# Altium schematic sheet Main.SchDoc(Main)
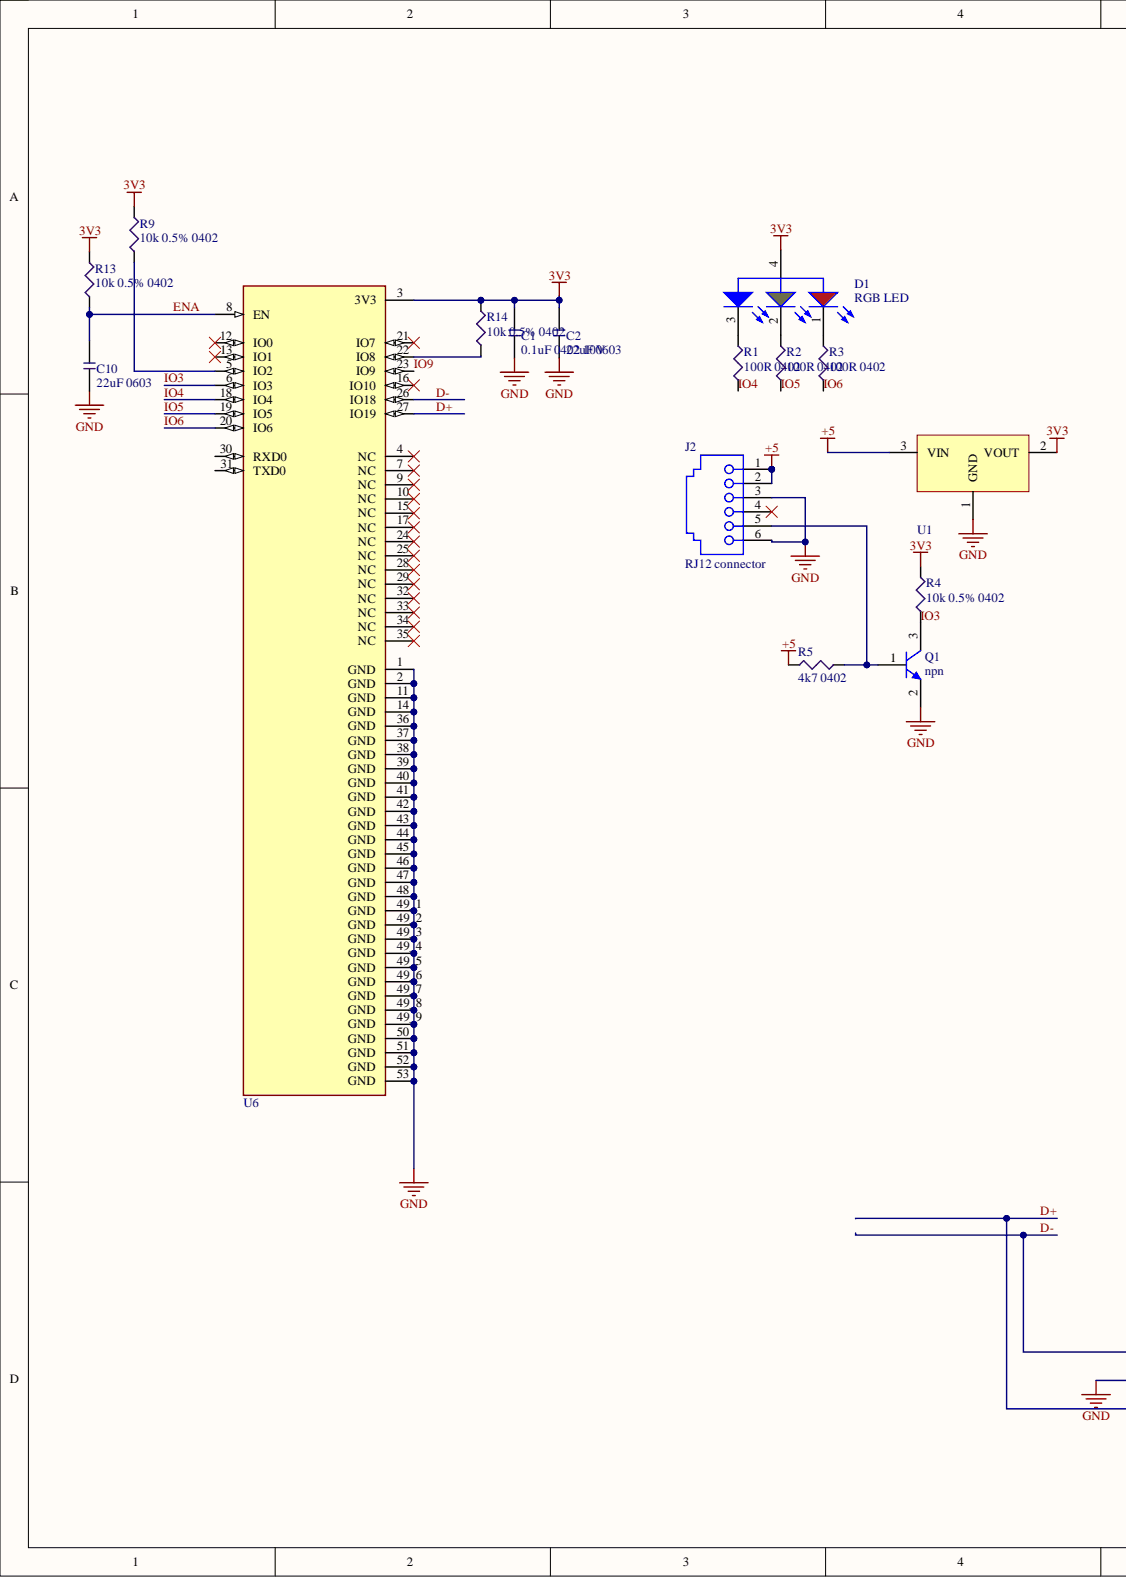
[diagram p0: Main.SchDoc, left]
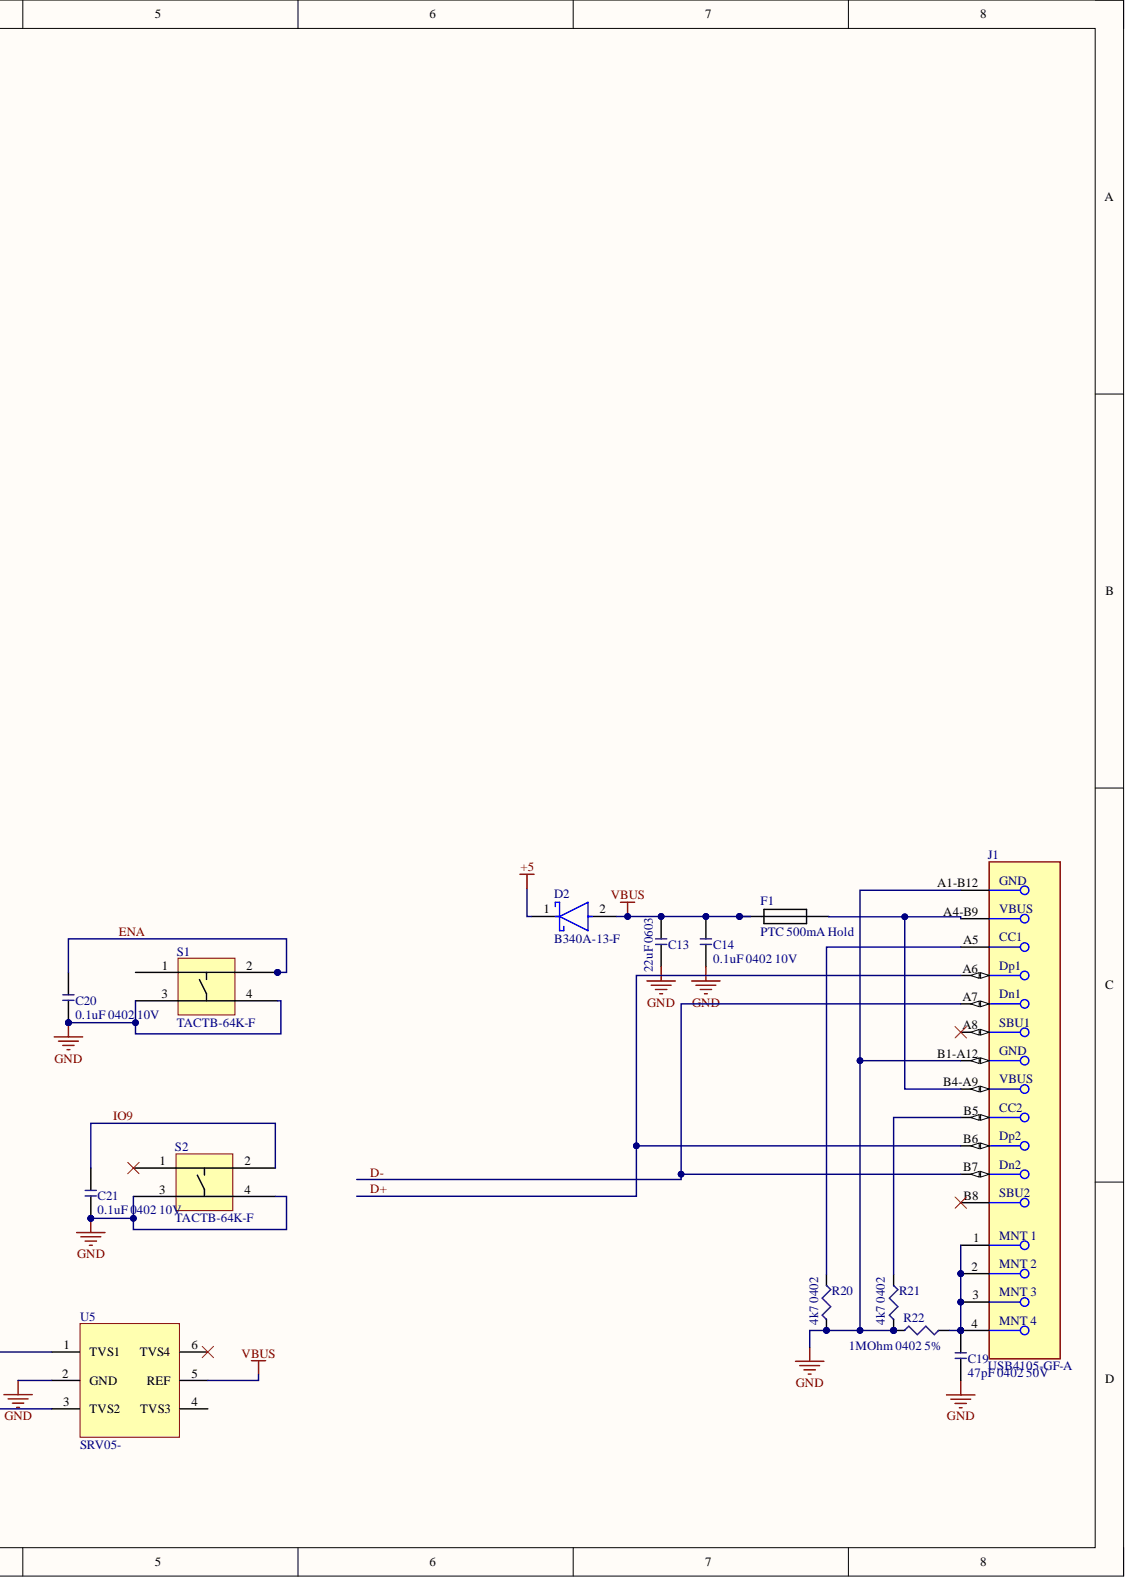
[diagram p1: Main.SchDoc, right]

## Parts
C1  0.1uF 0402 10V  [CAP_0402]
C2  22uF 0603  value 22uF  Capacitance: 22uF  Case Code (Imperial): 0603  [CAP_0603]
C10  22uF 0603  value 22uF  Capacitance: 22uF  Case Code (Imperial): 0603  [CAP_0603]
C13  22uF 0603  value 22uF  Capacitance: 22uF  Case Code (Imperial): 0603  [CAP_0603]
C14  0.1uF 0402 10V  [CAP_0402]
C19  47pF 0402 50V  value 47pF  [CAP_0402]
C20  0.1uF 0402 10V  [CAP_0402]
C21  0.1uF 0402 10V  [CAP_0402]
D1  RGB LED  [WL-SFCD_0606]
D2  B340A-13-F  [FP-SMA-MFG]
F1  PTC 500mA Hold  [PTC Fuse 1206]
J1  USB4105-GF-A  [FP-USB4105-GF-A-MFG]
J2  RJ12 connector  [615006138421]
Q1  npn  [ONSC-SOT-23-3-318-08_V]
R1  100R 0402  value 100R  [RES_0402]
R2  100R 0402  value 100R  Case/Package: 0402  [RES_0402]
R3  100R 0402  value 100R  Case/Package: 0402  [RES_0402]
R4  10k 0.5% 0402  value 10k  Tolerance: 0.5%  [RES_0402]
R5  4k7 0402  value 4k7  Case/Package: 0402  [RES_0402]
R9  10k 0.5% 0402  value 10k  Case/Package: 0402  Tolerance: 0.5%  [RES_0402]
R13  10k 0.5% 0402  value 10k  Tolerance: 0.5%  [RES_0402]
R14  10k 0.5% 0402  value 10k  [RES_0402]
R20  4k7 0402  value 4k7  Case/Package: 0402  [RES_0402]
R21  4k7 0402  value 4k7  Case/Package: 0402  [RES_0402]
R22  1MOhm 0402 5%  Case/Package: 0402  Tolerance: 5%  [RES_0402]
S1  TACTB-64K-F  [TACTB-64K-F]
S2  TACTB-64K-F  [TACTB-64K-F]
U1  3.3V LDO 1A  [SOT230P700X180-4N]  (value not drawn)
U5  SRV05-  [SOT95P280X145-6N]
U6  ESP32-C3-MINI-1-N4  [ESP32-C3-MINI-1-N4-Footprint-1]  (value not drawn)

## Nets
3V3: C1.2 C2.2 D1.4 R4.2 R9.2 R13.2 R14.2 U1.2 U6.3(3V3)
+5: D2.1 J2.1 J2.2 R5.2 U1.3
GND: C1.1 C2.1 C10.1 C13.1 C14.1 C19.1 C20.1 C21.1 J1.A1-B12 J1.B1-A12 J2.3 J2.6 Q1.2 R20.1 R21.1 R22.1 S1.3 S1.4 S2.3 S2.4 U1.1 U5.2(GND) U6.1(GND) U6.2(GND) U6.11(GND) U6.14(GND) U6.36(GND) U6.37(GND) U6.38(GND) U6.39(GND) U6.40(GND) U6.41(GND) U6.42(GND) U6.43(GND) U6.44(GND) U6.45(GND) U6.46(GND) U6.47(GND) U6.48(GND) U6.49_1(GND) U6.49_2(GND) U6.49_3(GND) U6.49_4(GND) U6.49_5(GND) U6.49_6(GND) U6.49_7(GND) U6.49_8(GND) U6.49_9(GND) U6.50(GND) U6.51(GND) U6.52(GND) U6.53(GND)
VBUS: C13.2 C14.2 D2.2 F1.1 U5.5(REF)
D+: J1.A6 J1.B6 U5.3(TVS2) U6.27(IO19)
D-: J1.A7 J1.B7 U5.1(TVS1) U6.26(IO18)
ENA: C10.2 C20.2 R13.1 S1.2 U6.8(EN)
IO3: Q1.3 R4.1 U6.6(IO3)
IO4: R1.1 U6.18(IO4)
IO5: R2.1 U6.19(IO5)
IO6: R3.1 U6.20(IO6)
IO9: C21.2 S2.2 U6.23(IO9)
NetC19_2: C19.2 J1.1 J1.2 J1.3 J1.4 R22.2
NetD1_1: D1.1 R3.2
NetD1_2: D1.2 R2.2
NetD1_3: D1.3 R1.2
NetF1_2: F1.2 J1.A4-B9 J1.B4-A9
NetJ1_A5: J1.A5 R20.2
NetJ1_B5: J1.B5 R21.2
NetJ2_5: J2.5 Q1.1 R5.1
NetR9_1: R9.1 U6.5(IO2)
NetR14_1: R14.1 U6.22(IO8)

Not connected: J1 A8 B8; J2 4; S1 1; S2 1; U5 4(TVS3) 6(TVS4); U6 4(NC) 7(NC) 9(NC) 10(NC) 12(IO0) 13(IO1) 15(NC) 16(IO10) 17(NC) 21(IO7) 24(NC) 25(NC) 28(NC) 29(NC) 30(RXD0) 31(TXD0) 32(NC) 33(NC) 34(NC) 35(NC)

## Library data of the parts
C1 C14 C20 C21 = CMP-00101-1: 0.1uF 0402 10V X7R 10%; Value: 100 nF; Component Kind: Standard; Library Name: ON Development Vault; Tolerance: 10 %; Voltage: 10 V
C2 C10 C13 = CMP-00148-1: Cap Ceramic 22uF 6.3V X5R 20% SMD 0603 85C Cardboard T/R; Case Code (Metric): 1608; Case/Package: 0603; Component Kind: Standard; Construction: Flat; Contact Plating: Tin; Depth: 0.8mm; Dielectric: X5R; Height: 0.8mm; Length: 1.6mm; Library Name: ON Development Vault; Material: Ceramic; Max Operating Temperature: 85°C; Min Operating Temperature: -55°C; Mount: Surface Mount; Package Quantity: 1; Packaging: Tape and Reel; Radiation Hardening: No; RoHS Compliant: Yes; Series: CL; Thickness: 0.039inch; Tolerance: 20%; Voltage: 6.3V; Voltage Rating: 6.3V; Width: 0.031inch
C19 = CMP-00099-1: 47pF 0402 50V X7R 5%; Tolerance: 10%; Component Kind: Standard; Library Name: ON Development Vault; Voltage: 50V
D1 = CMP-008-0004-0.0: WL-SFCD SMT full-color Chip LED Diffused; Forward Current: 20mA; Forward Voltage: 3.3V; Luminous Intensity: 900mcd; Viewing Angle: 120°; Case/Package: 0606; Component Kind: Standard; Height: 0.4mm; Length: 1.6mm; Lens Transparency: Diffused; Library Name: ON Development Vault; Max Operating Temperature: 85°C; Min Operating Temperature: -40°C; Orientation: Top View; Package Quantity: 2000; Packaging: Cut Tape; RoHS Compliant: Yes; Series: WL-SFCW; Weight: 0.000353oz; Width: 1.6mm
D2 = CMP-016-0019-1: Rectifier Diode, Schottky, 1 Phase, 1 Element, 3A, 40V V(RRM), Silicon; Capacitance: 250pF; Element Configuration: Single; Forward Voltage: 500mV; Max Forward Surge Current (Ifsm): 80A; Max Reverse Current: 500uA; Polarity: Standard; Average Rectified Current: 3A; Case/Package: SMA; Component Kind: Standard; Contact Plating: Tin,Matte; Current: 3A; Current Rating: 3A; Forward Current: 3A; Height: 2.3mm; Lead Free: Lead Free; Length: 4.6mm; Library Name: ON Development Vault; Max Operating Temperature: 125°C; Max Repetitive Reverse Voltage (Vrrm): 40V; Max Reverse Voltage (DC): 40V; Min Operating Temperature: -55°C; Mount: Surface Mount; Number of Pins: 2; Output Current: 3A; Package Quantity: 5000; Packaging: Tape and Reel; Peak Non-Repetitive Surge Current: 80A; Radiation Hardening: No; REACH SVHC: No SVHC; RoHS: Yes; RoHS Compliant: Yes; Series: -; Termination: SMD/SMT; Voltage: 40V; Voltage Rating (DC): 40V; Weight: 0.002258oz; Width: 2.92mm
F1 = CMP-017-0001-1: PTC RESET FUSE 8V 500MA 1206; Mfg. Part Number: 0ZCJ0050FF2G; Case/Package: 1206; Component Kind: Standard; Library Name: ON Development Vault; Mount: SMD; Pins: 2; RoHS: Yes
J1 = CMP-003-0034-0.0: Conn USB 2.0 Type C RCP 24 POS 0.3mm/0.5mm Solder RA SMD 24 Terminal 1 Port T/R; Gender: Receptacle; Mating Cycles: 10000; Number of Ports: 1; Shielding: Shielded; Component Kind: Standard; Connector Type: USB; Contact Plating: Gold; Flammability Rating: UL94 V-0; Insulation Color: Black; Library Name: ON Development Vault; Max Operating Temperature: 85°C; Min Operating Temperature: -40°C; Mount: Surface Mount,Through Hole,Horizontal; Series: USB4105; Termination: Solder
J2 = CMP-003-0042-0.0: Telecom and Datacom Connector, 6 Contact(s), Male, Right Angle, Solder Terminal, Locking, Receptacle; Connector Type: Jack; Current Rating: 1.5A; Direction: Up; Gender: Female; Insulation Resistance: 500MR; Mating Cycles: 500; Number of Contacts: 6; Number of Ports: 1; Shielding: Unshielded; Voltage Rating (AC): 120V; Color: Black; Component Kind: Standard; Contact Material: Copper Alloy,Copper; Contact Plating: Gold; Depth: 13mm; Flammability Rating: UL94 V-0; Height: 17.7mm; Housing Material: PBT; Length: 12.2mm; Library Name: ON Development Vault; Max Operating Temperature: 85°C; Min Operating Temperature: -40°C; Mount: Through Hole; Number of Positions: 6; Number of Rows: 1; Orientation: Right Angle; Packaging: Tray; Reach SVHC Compliant: Unknown; RoHS Compliant: Yes; Series: WR-MJ; Termination: Through Hole; Width: 13mm
Q1 = CMP-014-0017-1: NPN 40V 0.2A SOT23; Collector Base Voltage (VCBO): 80V; Collector Emitter Breakdown Voltage: 65V; Collector Emitter Saturation Voltage: 600mV; Current Rating: 100mA; Element Configuration: Single; Emitter Base Voltage (VEBO): 6V; Frequency: 100MHz; hFE Min: 200; Max Power Dissipation: 300mW; Number of Elements: 1; Case/Package: SOT-23-3; Collector Emitter Voltage (VCEO): 65V; Collector-emitter Voltage-Max: 600mV; Component Kind: Standard; Contact Plating: Tin,Matte; Gain Bandwidth Product: 100MHz; Halogen Free: Halogen Free; Height: 1mm; Lead Free: Lead Free; Length: 2.9mm; Library Name: ON Development Vault; Max Breakdown Voltage: 65V; Max Collector Current: 100mA; Max Frequency: 100MHz; Max Operating Temperature: 150°C; Min Operating Temperature: -55°C; Mount: Surface Mount; Number of Pins: 3; Package Quantity: 1; Packaging: Tape and Reel; Polarity: NPN; Power Dissipation: 300mW; Radiation Hardening: No; REACH SVHC: No SVHC; RoHS: Yes; RoHS Compliant: Yes; Series: -; Transition Frequency: 100MHz; Voltage Rating (DC): 65V; Width: 1.3mm
R1-R3 = CMP-001-0001-5: 100R 1% 0402 63mW; Component Kind: Standard; Library Name: ON Development Vault; Power: 63mW; Tolerance: 1%
  R1: Case/Package: 0402
R4 R9 R13 R14 = CMP-0001-00029-2: RES 10K OHM 0.5% 1/16W 0402; Manufacturer Part No.: RC0402DR-0710KL; Component Kind: Standard; Library Name: ON Development Vault
  R4 R13: Case/Package: 0402
  R14: Case/Package: 0402; Tolerance: 0.5%
R5 R20 R21 = CMP-001-0029-3: RES SMD 4.7K OHM 1% 1/16W 0402; Mfg. Part Number: RC1005F472CS; Tolerance: 1; Component Kind: Standard; Library Name: ON Development Vault; Power: 1/16W
R22 = CMP-001-0065-1: RES SMD 1M OHM 5% 1/16W 0402; Mfg. Part Number: CR0402JC1MS001; Value: 1Mega; Component Kind: Standard; Library Name: ON Development Vault; Power: 1/16 W
S1 S2 = CMP-013-0002-1: Microswitch TACT; SPST-NO; Positions:2; 0.05A/12VDC;; Case/Package: -; Component Kind: Standard; Library Name: ON Development Vault; Mfg. Part No.: TACTB-64K-F; Mount: SMD; Pins: 4; RoHS: Yes
U1 = CMP-00114-2: IC REG LINEAR 3.3V 1A SOT223; Accuracy: 1%; Dropout Voltage: 1.1V; Max Input Voltage: 13V; Number of Outputs: 1; Operating Supply Current: 3.5mA; Output Type: Fixed; Polarity: Positive; Power Supply Rejection Ratio (PSRR): 70dB; Reference Voltage: 1.262V; Case/Package: TO-261-4; Component Kind: Standard; Contact Plating: Tin; Lead Free: Lead Free; Library Name: ON Development Vault; Max Operating Temperature: 125°C; Max Output Current: 1A; Min Operating Temperature: -40°C; Mount: Surface Mount; Number of Pins: 3; Number of Regulators: 1; Output Current: 1A; Output Voltage: 3.3V; Package Quantity: 4000; Packaging: Tape and Reel; Quiescent Current: 3.5mA; Weight: 0.000282oz
U5 = CMP-016-0020-1: SRV05 Series 4 Ch 3.5 pF 15 Vclamp Steering Diode/TVS Array Combo - SOT-23-6; Capacitance: 3.5pF; Clamping Voltage: 15V; Direction: Unidirectional; Leakage Current: 5uA; Number of Channels: 4; Number of Elements: 1; Peak Pulse Current: 5A; Peak Pulse Power: 500W; Reverse Breakdown Voltage: 6V; Reverse Standoff Voltage: 5V; Test Current: 1mA; Case/Package: SOT-23; Component Kind: Standard; Depth: 1.75mm; Lead Free: Lead Free; Length: 3.05mm; Library Name: ON Development Vault; Max Operating Temperature: 150°C; Min Operating Temperature: -55°C; Mount: Surface Mount; Number of Pins: 6; Packaging: Tape and Reel; Polarity: Unidirectional; Radiation Hardening: No; RoHS: Yes; RoHS Compliant: Yes; Working Voltage: 5V
U6 = CMP-00162-1: Bluetooth, WiFi 802.11b/g/n, Bluetooth v5.0 Transceiver Module 2.412GHz ~ 2.484GHz PCB Trace Surface Mount; Component Kind: Standard; Library Name: ON Development Vault; Manufacturer Part No.: ESP32-C3-MINI-1-N4
